# Altium schematic sheet lv_power_motor.SchDoc(lv_power_motor)
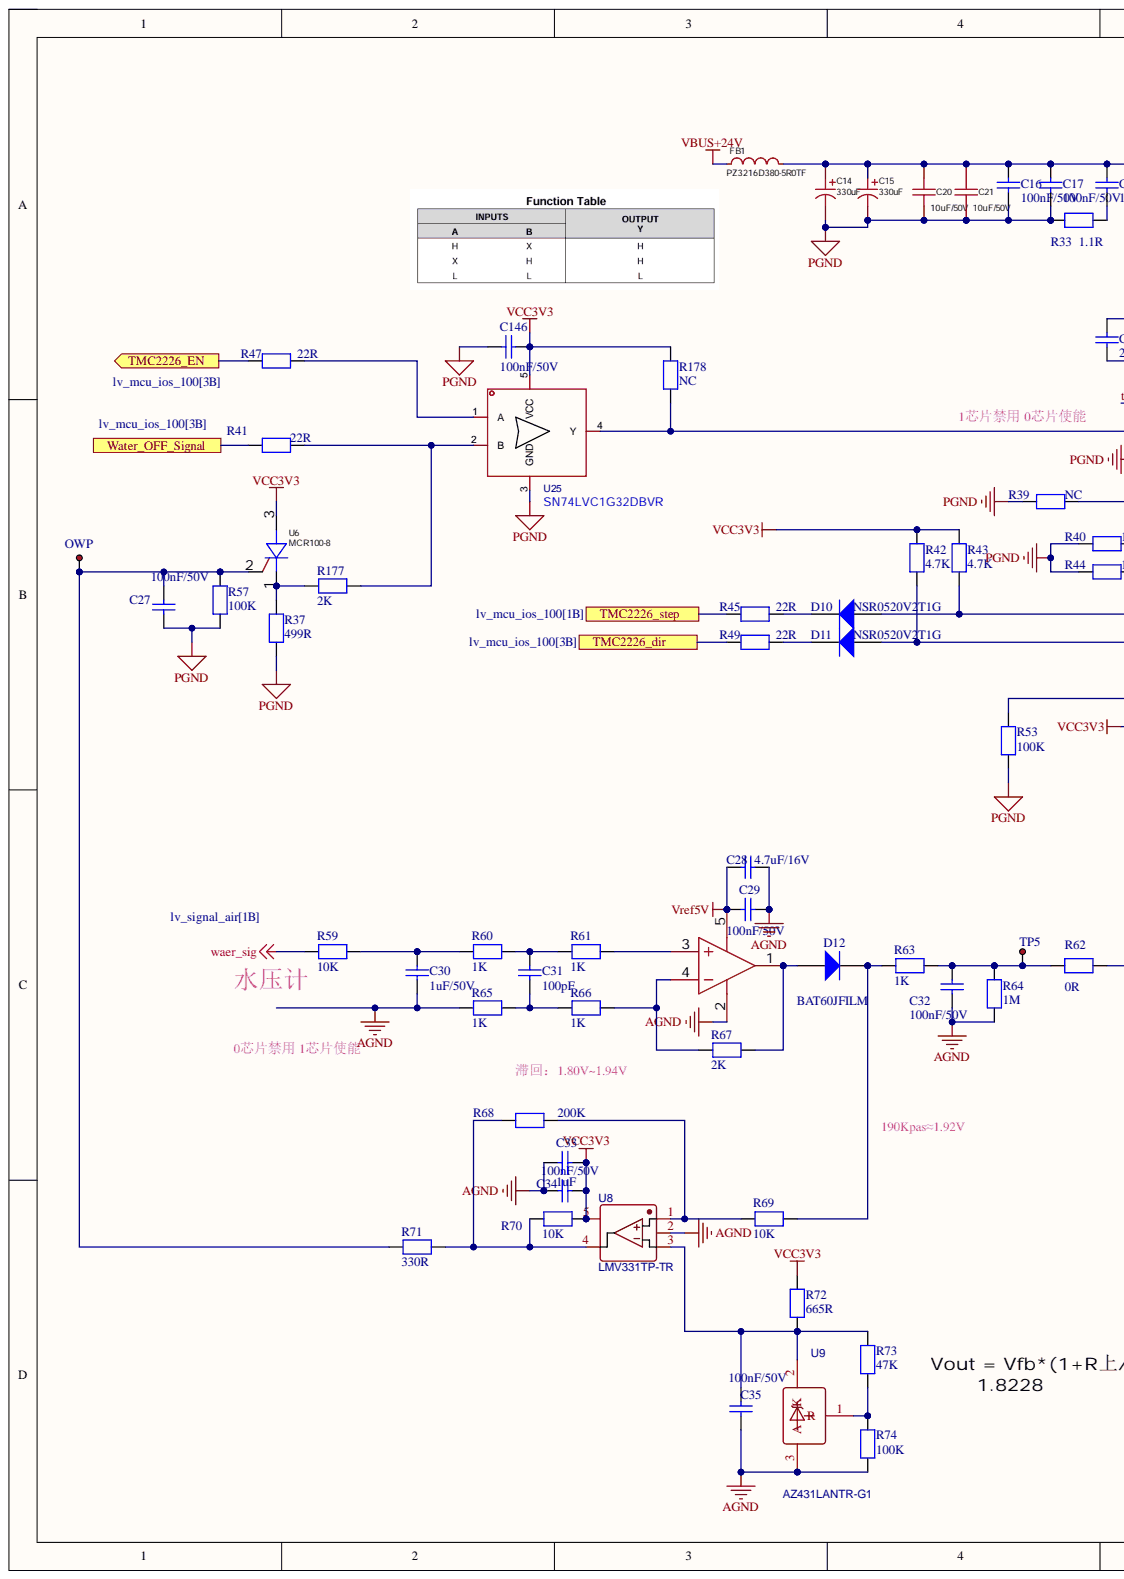
[diagram p0: lv_power_motor.SchDoc, left]
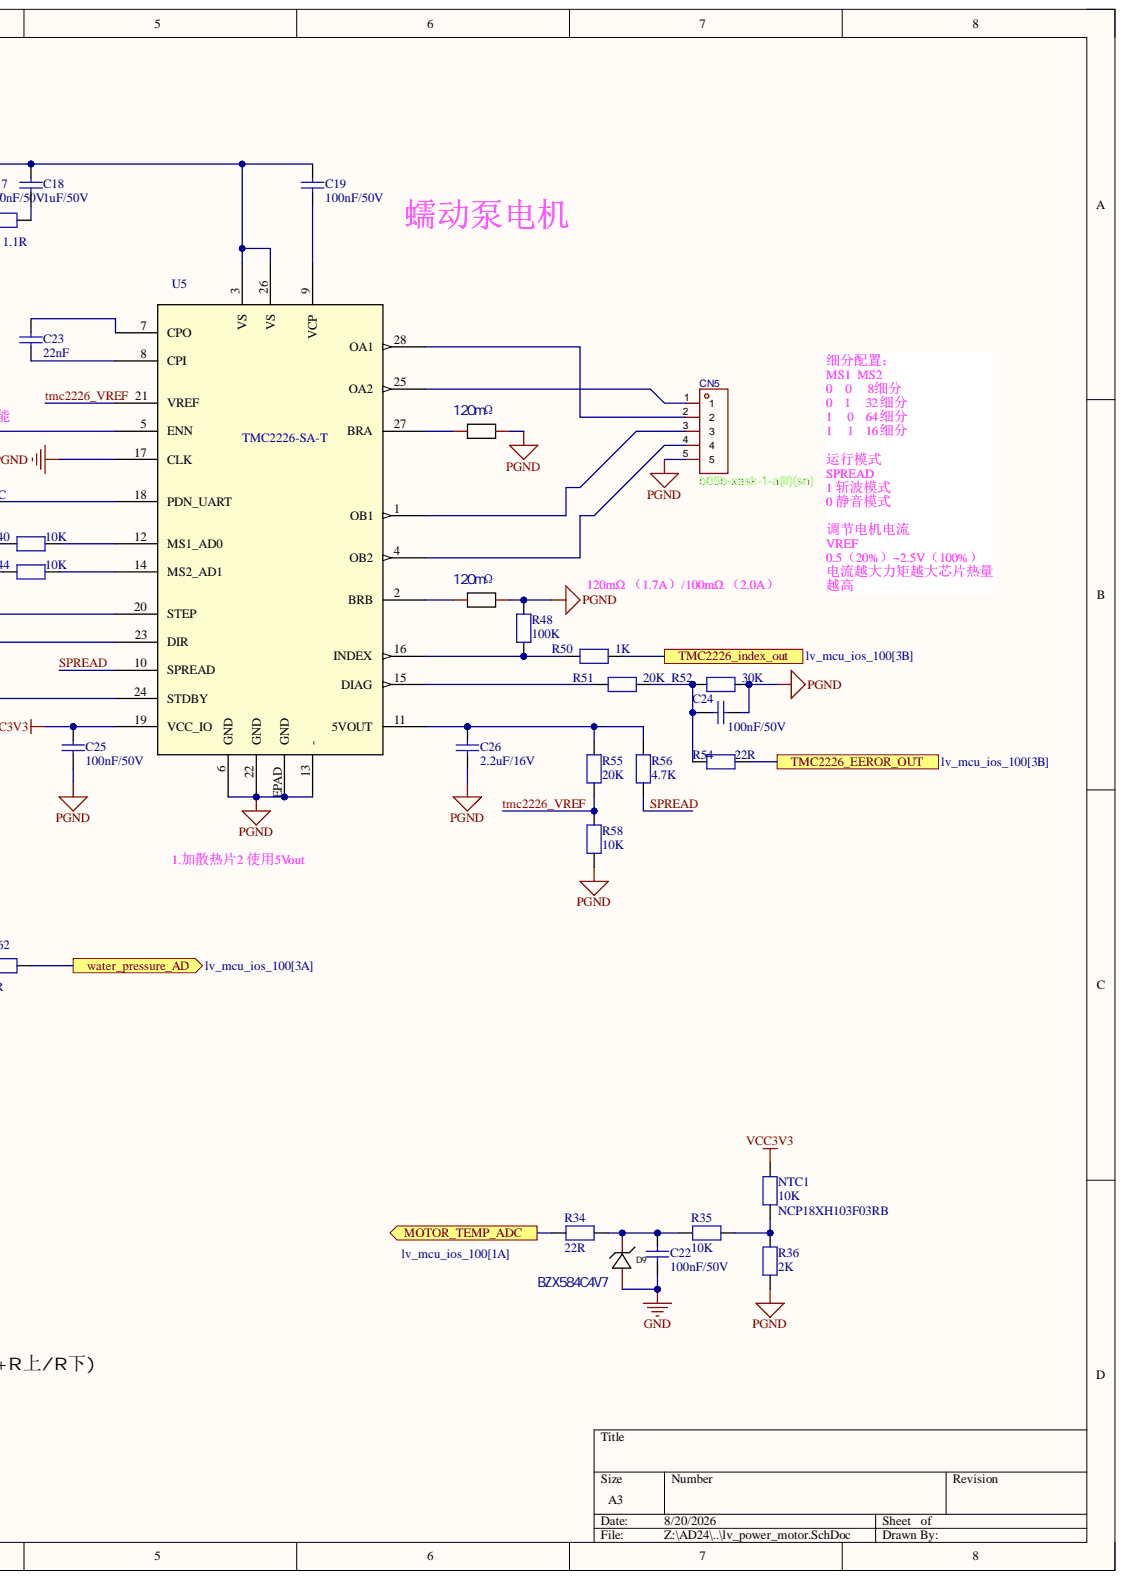
[diagram p1: lv_power_motor.SchDoc, right]

## Parts
C14  330uF  [CAP-SMD_BD10.0-L10.3-W10.3-LS10.9-FD]
C15  330uF  [CAP-SMD_BD10.0-L10.3-W10.3-LS10.9-FD]
C16  100nF/50V  [C0603]
C17  100nF/50V  [C0603]
C18  1uF/50V  [C1210]
C19  100nF/50V  [C0603]
C20  10uF/50V  [C2220]
C21  10uF/50V  [C2220]
C22  100nF/50V  [C0402]
C23  22nF  [C0603]
C24  100nF/50V  [C0402]
C25  100nF/50V  [C0402]
C26  2.2uF/16V  [C0603]
C27  100nF/50V  [C0402]
C28  4.7uF/16V  [C0603]
C29  100nF/50V  [C0402]
C30  1uF/50V  [C0603]
C31  100pF  [C0402]
C32  100nF/50V  [C0402]
C33  value 1uF  [C0603]  (hidden comment: 1uF/50V)
C34  100nF/50V  [C0402]
C35  100nF/50V  [C0402]
C146  100nF/50V  [C0402]
CN5  b05b-xask-1-a(lf)(sn)  [CONN-TH_B05B-XASK-1-A-LF-SN]
D9  BZX584C4V7  [SOD-523F_L1.2-W0.8-LS1.7-RD]
D10  NSR0520V2T1G  [SOD-523F_L1.2-W0.8-LS1.7-RD]
D11  NSR0520V2T1G  [SOD-523F_L1.2-W0.8-LS1.7-RD]
D12  BAT60JFILM  [DIO_SOD323]
FB1  PZ3216D380-5R0TF  [L1206]
NTC1  NCP18XH103F03RB  value 10K  [R0603]
R33  1.1R  [R0603]
R34  22R  [R0402]
R35  10K  [R0402]
R36  2K  [R0402]
R37  499R  [R0603]
R38  120mΩ  [RES-SMD_L6.4-W3.2_C500733]
R39  NC  [R0402]
R40  10K  [R0402]
R41  22R  [R0402]
R42  4.7K  [R0402]
R43  4.7K  [R0402]
R44  10K  [R0402]
R45  22R  [R0402]
R46  120mΩ  [RES-SMD_L6.4-W3.2_C500733]
R47  22R  [R0402]
R48  100K  [R0402]
R49  22R  [R0402]
R50  1K  [R0402]
R51  20K  [R0402]
R52  30K  [R0402]
R53  100K  [R0603]
R54  22R  [R0402]
R55  20K  [R0402]
R56  4.7K  [R0402]
R57  100K  [R0402]
R58  10K  [R0402]
R59  10K  [R0402]
R60  1K  [R0402]
R61  1K  [R0402]
R62  0R  [R0402]
R63  1K  [R0402]
R64  1M  [R0402]
R65  1K  [R0402]
R66  1K  [R0402]
R67  2K  [R0402]
R68  200K  [R0402]
R69  10K  [R0402]
R70  10K  [R0402]
R71  330R  [R0402]
R72  665R  [R0402]
R73  47K  [R0402]
R74  100K  [R0402]
R177  2K  [R0402]
R178  NC  [R0603]
TP3  OWP  [TP_SMD_1MM]
TP5  (no value)  [TP_SMD_1MM]
U5  TMC2226-SA-T  [HTSSOP_26-SA-T_TRI]
U6  MCR100-8  [SOT-23-3_L2.9-W1.3-P1.90-LS2.4-BR]
U7  TLV271CDBVR  [SOT-23-5_L3.0-W1.7-P0.95-LS2.8-BR]  (value not drawn)
U8  LMV331TP-TR  [SOT-23-5_L3.0-W1.7-P0.95-LS2.8-BR]
U9  AZ431LANTR-G1  [SOT-23-3_L2.9-W1.6-P1.90-LS2.8-BR]
U25  SN74LVC1G32DBVR  [SOT-23-5_L3.0-W1.7-P0.95-LS2.8-BR]

## Ports
MOTOR_TEMP_ADC, TMC2226_dir, TMC2226_EEROR_OUT, TMC2226_EN, TMC2226_index_out, TMC2226_step, Water_OFF_Signal, water_pressure_AD

## Nets
AGND: C28.1 C29.2 C30.2 C32.1 C33.1 C34.2 C35.2 R64.1 R65.1 R74.1 U7.2 U8.2 U9.3
GND: C22.2 D9.2
PGND: C14.2 C15.2 C16.1 C17.1 C20.2 C21.2 C24.2 C25.2 C26.2 C27.2 C146.2 CN5.5 R33.2 R36.1 R37.1 R38.2 R39.2 R40.1 R44.1 R46.2 R48.1 R52.1 R53.1 R57.1 R58.2 U5.6(GND) U5.13(-) U5.17(CLK) U5.22(GND) U5.EPAD(GND) U25.3(GND)
VBUS+24V: FB1.1
VCC3V3: C25.1 C33.2 C34.1 C146.1 NTC1.2 R42.1 R43.1 R70.2 R72.2 R178.2 U5.19(VCC_IO) U6.3 U8.5 U25.5(VCC)
Vref5V: C28.2 C29.1 U7.5
waer_sig: R59.1
MOTOR_TEMP_ADC: R34.2 ; port MOTOR_TEMP_ADC
SPREAD: R56.2 U5.10(SPREAD)
TMC2226_dir: R49.1 ; port TMC2226_dir
TMC2226_EEROR_OUT: R54.2 ; port TMC2226_EEROR_OUT
TMC2226_EN: R47.1 ; port TMC2226_EN
TMC2226_index_out: R50.1 ; port TMC2226_index_out
TMC2226_step: R45.1 ; port TMC2226_step
tmc2226_VREF: R55.2 R58.1 U5.21(VREF)
Water_OFF_Signal: R41.2 ; port Water_OFF_Signal
water_pressure_AD: R62.1 ; port water_pressure_AD
NetC14_1: C14.1 C15.1 C16.2 C17.2 C18.2 C19.2 C20.1 C21.1 FB1.2 U5.3(VS) U5.26(VS)
NetC18_1: C18.1 R33.1
NetC19_1: C19.1 U5.9(VCP)
NetC22_1: C22.1 D9.1 R34.1 R35.2
NetC23_1: C23.1 U5.8(CPI)
NetC23_2: C23.2 U5.7(CPO)
NetC24_1: C24.1 R51.1 R52.2 R54.1
NetC26_1: C26.1 R55.1 R56.1 U5.11(5VOUT)
NetC27_1: C27.1 R57.2 R71.1 TP3.1 U6.2
NetC30_1: C30.1 R59.2 R60.1
NetC31_1: C31.1 R60.2 R61.1
NetC31_2: C31.2 R65.2 R66.1
NetC32_2: C32.2 R62.2 R63.2 R64.2 TP5.1
NetC35_1: C35.1 R72.1 R73.2 U8.3 U9.2
NetCN5_1: CN5.1 U5.25(OA2)
NetCN5_2: CN5.2 U5.28(OA1)
NetCN5_3: CN5.3 U5.1(OB1)
NetCN5_4: CN5.4 U5.4(OB2)
NetD10_1: D10.1 R45.2
NetD10_2: D10.2 R43.2 U5.20(STEP)
NetD11_1: D11.1 R49.2
NetD11_2: D11.2 R42.2 U5.23(DIR)
NetD12_1: D12.1 R67.1 U7.1
NetD12_2: D12.2 R63.1 R69.1
NetNTC1_1: NTC1.1 R35.1 R36.2
NetR37_2: R37.2 R177.2 U6.1
NetR38_1: R38.1 U5.27(BRA)
NetR39_1: R39.1 U5.18(PDN_UART)
NetR40_2: R40.2 U5.12(MS1_AD0)
NetR41_1: R41.1 R177.1 U25.2(B)
NetR44_2: R44.2 U5.14(MS2_AD1)
NetR46_1: R46.1 U5.2(BRB)
NetR47_2: R47.2 U25.1(A)
NetR48_2: R48.2 R50.2 U5.16(INDEX)
NetR51_2: R51.2 U5.15(DIAG)
NetR53_2: R53.2 U5.24(STDBY)
NetR61_2: R61.2 U7.3
NetR66_2: R66.2 R67.2 U7.4
NetR68_1: R68.1 R69.2 U8.1
NetR68_2: R68.2 R70.1 R71.2 U8.4
NetR73_1: R73.1 R74.2 U9.1
NetR178_1: R178.1 U5.5(ENN) U25.4(Y)

## Library data of the parts
C14 C15 = HV1V337M1010PZ: 330uF, 35V, 20%; Manufacturer: HONOR(荣誉); Add into BOM: yes; L: 10mm; Component Kind: Standard; Convert to PCB: yes; Datasheet: https://atta.szlcsc.com/upload/public/pdf/source/20240802/61683F5000C7CFED5606ABBE3CFBE0F5.pdf; Device: HV1V337M1010PZ; JLCPCB Part Class: Extended Part; LCSC Part Name: 330uF ±20% 35V; Library Name: HiPin_Library_AD.IntLib; Manufacturer Part: HV1V337M1010PZ; Operating Temperature: -55℃~+105℃; Rated Voltage: 35V; Supplier: LCSC; Supplier Footprint: SMD,D10xL10mm; Supplier Part: C5246558; Symbol: HV1V337M1010PZ; Tolerance: ±20%; Value: 330uF; φD: 10mm
C16 C17 C19 = Capacitor_1: 50V, X7R, 10%; Manufacturer: muRata; Manufacturer_Part_Number: GRM188R71H104KA93D; Component Kind: Standard; ComponentLink1Description: Yageo_Capacitor_X5R-series.pdf; ComponentLink1URL: http://tunmcdsvn.tun.st.com/Component_Datasheet_Library/Trunk/Capacitors/Yageo_Multilayer_capacitor_X5R_series_100pF_to_100uF; Library Name: HiPin_Library_AD.IntLib
C18 = Capacitor_3: 50V, X7R, 10%; Manufacturer: YAGEO; Manufacturer_Part_Number: CC1210KKX7R9BB105; Component Kind: Standard; Library Name: HiPin_Library_AD.IntLib
C20 C21 = FS55X106K500EGG: 50V, X7R, 10%; Manufacturer: PSA(信昌电陶); Add into BOM: yes; Value: 10uF; Component Kind: Standard; Convert to PCB: yes; Datasheet: https://atta.szlcsc.com/upload/public/pdf/source/20190723/C381466_B1CCDB289216E7EE775C98769E222CFD.pdf; Device: FS55X106K500EGG; JLCPCB Part Class: Extended Part; LCSC Part Name: 10uF ±10% 50V; Library Name: HiPin_Library_AD.IntLib; Manufacturer Part: FS55X106K500EGG; Supplier: LCSC; Supplier Footprint: 2220; Supplier Part: C153034; Symbol: FS55X106K500EGG; Temperature Coefficient: X7R; Tolerance: ±10%; Voltage Rated: 50V
C22 C24 C25 = Capacitor_2: 50V, X7R , 10%; Manufacturer: muRata; Manufacturer_Part_Number: GRM155R71H104KE14D; Component Kind: Standard; ComponentLink1Description: Datasheet; ComponentLink1URL: http://tunmcdsvn.tun.st.com/Component_Datasheet_Library/Trunk/Capacitors/Yageo_Multilayer_capacitor_X5R_series_100pF_to_100uF; Library Name: HiPin_Library_AD.IntLib
C23 = Capacitor_1: 50V, X7R, 10%; Manufacturer: muRata; Manufacturer_Part_Number: GCJ188R71H223KA01D; Component Kind: Standard; ComponentLink1Description: Yageo_Capacitor_X5R-series.pdf; ComponentLink1URL: http://tunmcdsvn.tun.st.com/Component_Datasheet_Library/Trunk/Capacitors/Yageo_Multilayer_capacitor_X5R_series_100pF_to_100uF; Library Name: HiPin_Library_AD.IntLib
C26 = Capacitor_2: 16V, X7R , 10%; Manufacturer: YAGEO; Manufacturer_Part_Number: CC0603KRX7R7BB225; Component Kind: Standard; ComponentLink1Description: Datasheet; ComponentLink1URL: http://tunmcdsvn.tun.st.com/Component_Datasheet_Library/Trunk/Capacitors/Yageo_Multilayer_capacitor_X5R_series_100pF_to_100uF; Library Name: HiPin_Library_AD.IntLib
C27 C34 C35 = Capacitor: 50V, 10%, X7R; Internal_Part_Number: MCD-00003; Manufacturer: muRata; Manufacturer_Part_Number: GRM155R71H104KE14D; Value: 100nF; Component Kind: Standard; ComponentLink1Description: Datasheet; ComponentLink1URL: http://tunmcdsvn.tun.st.com/Component_Datasheet_Library/Trunk/Capacitors/Yageo_Capacitor_NP0_16V-to-50V; Library Name: HiPin_Library_AD.IntLib; Package: C0402
C28 = Capacitor_1: 16V, X7S, 10%; Internal_Part_Number: MCD-00009; Manufacturer: muRata; Manufacturer_Part_Number: GRM188C71C475KE21D; Component Kind: Standard; ComponentLink1Description: Yageo_Capacitor_X5R-series.pdf; ComponentLink1URL: http://tunmcdsvn.tun.st.com/Component_Datasheet_Library/Trunk/Capacitors/Yageo_Multilayer_capacitor_X5R_series_100pF_to_100uF; Library Name: HiPin_Library_AD.IntLib; Package: C0603
C29 C32 C146 = Capacitor: 50V, 5%, X7R; Manufacturer: muRata; Manufacturer_Part_Number: GRM155R71H104KE14D; Component Kind: Standard; ComponentLink1Description: Datasheet; ComponentLink1URL: http://tunmcdsvn.tun.st.com/Component_Datasheet_Library/Trunk/Capacitors/Yageo_Capacitor_NP0_16V-to-50V; Library Name: HiPin_Library_AD.IntLib
C30 = Capacitor_1: 50V, X5R, 10%; Manufacturer: muRata; Manufacturer_Part_Number: GRM188R61H105KAALD; Component Kind: Standard; ComponentLink1Description: Yageo_Capacitor_X5R-series.pdf; ComponentLink1URL: http://tunmcdsvn.tun.st.com/Component_Datasheet_Library/Trunk/Capacitors/Yageo_Multilayer_capacitor_X5R_series_100pF_to_100uF; Library Name: HiPin_Library_AD.IntLib
C31 = Capacitor: 50V, 5%, C0G; Manufacturer: muRata; Manufacturer_Part_Number: GRM1555C1H101JA01D; Component Kind: Standard; ComponentLink1Description: Datasheet; ComponentLink1URL: http://tunmcdsvn.tun.st.com/Component_Datasheet_Library/Trunk/Capacitors/Yageo_Capacitor_NP0_16V-to-50V; Library Name: HiPin_Library_AD.IntLib
C33 = MCD-00010: 50V, X5R, 10%; Manufacturer: YAGEO; Manufacturer_Part_Number: CC0603KRX5R7BB105; Part_Type: Capacitor; Database Table Name: MCD_PARTS$; Initial_Board_Reference: MB1274; Provided_By: Subcon; Component Kind: Standard; ComponentLink1Description: Yageo_Capacitor_X5R-series.pdf; ComponentLink1URL: http://tunmcdsvn.tun.st.com/Component_Datasheet_Library/Trunk/Capacitors/Yageo_Multilayer_capacitor_X5R_series_100pF_to_100uF; ECCN_EU: n/a; ECCN_US: n/a; Internal_Part_Number: MCD-00010; Library Name: MCD_PARTS_database.DbLib; Package: C0603; Status: Released
CN5 = b05b-xask-1-a(lf)(sn): 插针结构:1x5P;间距:2.5mm;安装方式:直插;参考系列:XA;总PIN数:5P;排数:1;每排PIN数:5;行距:-;额定电流:3A;触头材质:铜合金;触头镀层:锡;工作温度:-25℃~+85℃;; Manufacturer: JST; AddInBom: yes; Current Rating: 3A; Flame Retardant Rating: UL94V-0; ModelId: e0ab7f3b215b4606a0772da92fe8ba27; ModelTransform: 590.58937,248.031,0,0,0,0,0,0,-118.11; Number of PINs Per Row: 5; Pins Structure: 1x5P; Pitch: 2.5mm; Plastic Material: 玻纤增强PA66; Reference Series: XA; Supplementary Features: 打K脚；带柱; Voltage Rating: 250V; X-Length of Bottom Edge on Board (Spacing Line): 15mm; Y-Width of Bottom Edge on Board: 6.4mm; Z-Height of the Board: 8.8mm; Color: 白色; Component Kind: Standard; Contact Material: 铜合金; Contact Plating: 锡; ConvertToPcb: yes; Datasheet: https://item.szlcsc.com/datasheet/B05B-XASK-1-A(LF)(SN)/502110.html; FootprintName: CONN-TH_B05B-XASK-1-A-LF-SN; JLCPCB Part Class: Extended Part; LCSC Part Name: 1x5P 间距:2.5mm 直插 系列:XA; Library Name: HiPin_Library_AD.IntLib; ManufacturerPart: B05B-XASK-1-A(LF)(SN); ModelName: CONN-TH_B05B-XASK-1-A-LF-SN; Mounting Type: 直插; Number of Pins: 5P; Number of Rows: 1; Operating Temperature: -25℃~+85℃; Row Spacing: -; Supplier: LCSC; Supplier Footprint: 插件,P=2.5mm; SupplierPart: C491708; Symbol: B05B-XASK-1-A(LF)(SN)
D9 = LM5Z3V6T1G: 4.8~5.4V, 200mW; Power Dissipation: 500mW; Component Kind: Standard; Library Name: HiPin_Library_AD.IntLib; Manufacturer Part: BZX584C4V7; Supplier Footprint: SOD-123; Tolerance: -
D10 D11 = 1N4148: Component Kind: Standard; Library Name: HiPin_Library_AD.IntLib
D12 = BAT60JFILM: Internal_Part_Number: MCD-00034; Manufacturer: STMICROELECTRONICS; Part_Type: Diode; ECCN_EU: NEC; ECCN_US: EAR99; Initial_Board_Reference: MB1274; Provided_By: Subcon; Component Kind: Standard; ComponentLink1Description: STM_Diode_BAT60JFILM-SOD323.pdf; ComponentLink1URL: http://tunmcdsvn.tun.st.com/Component_Datasheet_Library/Trunk/Diodes/STM_Diode_BAT60JFILM-SOD323; Description: BAT60JFILM; Library Name: HiPin_Library_AD.IntLib; Manufacturer_Part_Number: BAT60JFILM; Status: Released; Value: BAT60JFILM
FB1 = BLM31PG601SN1L: 38Ω@100MHz 5A 15mΩ ±25%; Component Kind: Standard; Library Name: HiPin_Library_AD.IntLib
NTC1 = RES: 1% 3380K; Component Kind: Standard; Library Name: HiPin_Library_AD.IntLib
R33 R34 R36 R37 R39 R41-R43 R45 R47-R57 R60-R68 R71 R177 R178 = RES: 1%; Value: 10K; Component Kind: Standard; Library Name: HiPin_Library_AD.IntLib
R35 R40 R44 R58 R59 R69 R70 = RES: 1%; Component Kind: Standard; Library Name: HiPin_Library_AD.IntLib; Value: 10K
R38 R46 = PA2512FKE070R005E: 120mΩ，1W，1%; Manufacturer: YAGEO(国巨); Manufacturer Part: RL2512JK-070R12L; Component Kind: Standard; Library Name: HiPin_Library_AD.IntLib; PCB3D: RES-SMD_L6.4-W3.2_C500733
R72-R74 = RES: Resistor; Component Kind: Standard; Library Name: HiPin_Library_AD.IntLib
TP3 TP5 = PT: 测试点帽子; Component Kind: Standard; Library Name: HiPin_Library_AD.IntLib
U5 = TMC2226-SA-T: No Description Available; Mfr_Name: Analog Devices Inc; Component Kind: Standard; Library Name: HiPin_Library_AD.IntLib; RefDes: RefDes; Type: Type
U6 = MCR100-8_C5184437: 可控硅; Manufacturer: HXY MOSFET(华轩阳电子); Add into BOM: yes; Average Gate Power Dissipation (PG(AV)): 100mW; Gate Trigger   Voltage (Vgt): 800mV; Gate Trigger Current(Igt): 60uA; Holding Current (Ih): 5mA; Peak non-repetitive surge current (Itsm@f): 8A; Peak Repetitive Off?State Voltage (Vdrm): 600V; RMS On-State Current(It (rms)): 800mA; SCR Type: 单向可控硅; Voltage - On State (Vtm): 1.7V; Component Kind: Standard; Convert to PCB: yes; Datasheet: https://atta.szlcsc.com/upload/public/pdf/source/20240902/7358CD860A43FFBE3B53FCDEB35A6F8C.pdf; Device: MCR100-8_C5184437; JLCPCB Part Class: Extended Part; LCSC Part Name: 单向 Vgt: 800mV IT:0.8A Vdrm: 600V; Library Name: HiPin_Library_AD.IntLib; Manufacturer Part: MCR100-8; Operating Temperature: -; PCB3D: SOT-23-3_L2.9-W1.3-P1.90-LS2.4-BR-CW; Supplier: LCSC; Supplier Footprint: SOT-23; Supplier Part: C5184437; Symbol: MCR100-8_C5184437
U7 = TLV271CDBVR: 单电源运放; Manufacturer: TI(德州仪器); Add into BOM: yes; Current - Input Bias(Ib): 1pA; Gain Bandwidth Product(GBP): 3MHz; Number of Circuits: 单路; Output Current: 8mA; Slew Rate(SR): 2.6V/us; Supply Current: 625uA; Component Kind: Standard; Convert to PCB: yes; Datasheet: https://www.ti.com/cn/lit/gpn/tlv271; Device: TLV271CDBVR; JLCPCB Part Class: Extended Part; LCSC Part Name: TLV271CDBVR; Library Name: HiPin_Library_AD.IntLib; Manufacturer Part: TLV271CDBVR; Operating Temperature: 0℃~+70℃; Output Type: -; Rail to Rail: -; Supplier: LCSC; Supplier Footprint: SOT-23-5; Supplier Part: C131948; Symbol: TLV271CDBVR
U8 = LMV331TP-TR: 比较器; BOM_Manufacturer: 3PEAK; Contributor: LCSC; BOM_JLCPCB Part Class: Extended Part; BOM_Manufacturer Part: LMV331TP-TR; BOM_Supplier: LCSC; BOM_Supplier Part: C108095; Component Kind: Standard; Library Name: HiPin_Library_AD.IntLib; spicePre: U; spiceSymbolName: LMV331TP-TR
U9 = TL431: 参考电源; BOM_Manufacturer: JSMSEMI; Contributor: LCSC; BOM_JLCPCB Part Class: Extended Part; BOM_Manufacturer Part: TL431; BOM_Supplier: LCSC; BOM_Supplier Part: C963380; Component Kind: Standard; Library Name: HiPin_Library_AD.IntLib; spicePre: U; spiceSymbolName: TL431_C963380
U25 = SN74LVC1G32DBVR: 或门; Symbol: SN74AHC1G02DBVR; Component Kind: Standard; Library Name: HiPin_Library_AD.IntLib
